# Altium schematic sheet MCU.SchDoc(MCU)
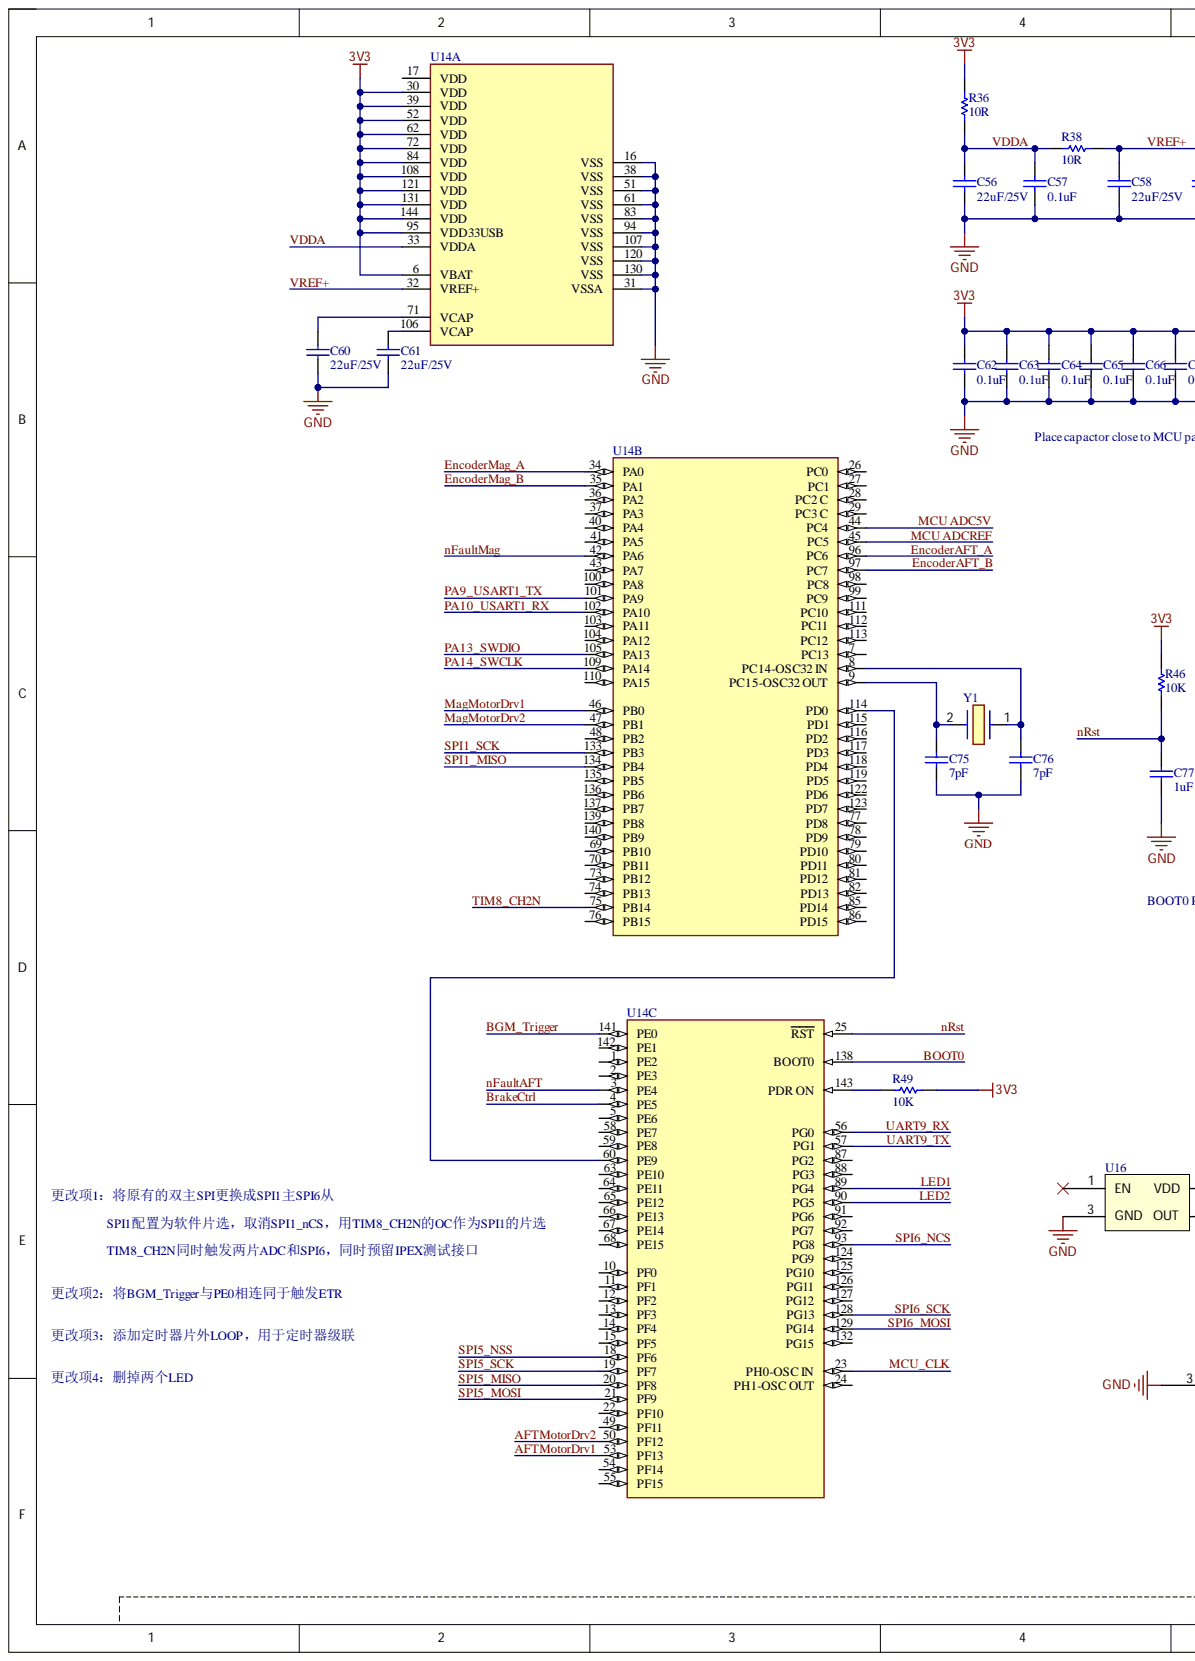
[diagram p0: MCU.SchDoc, left]
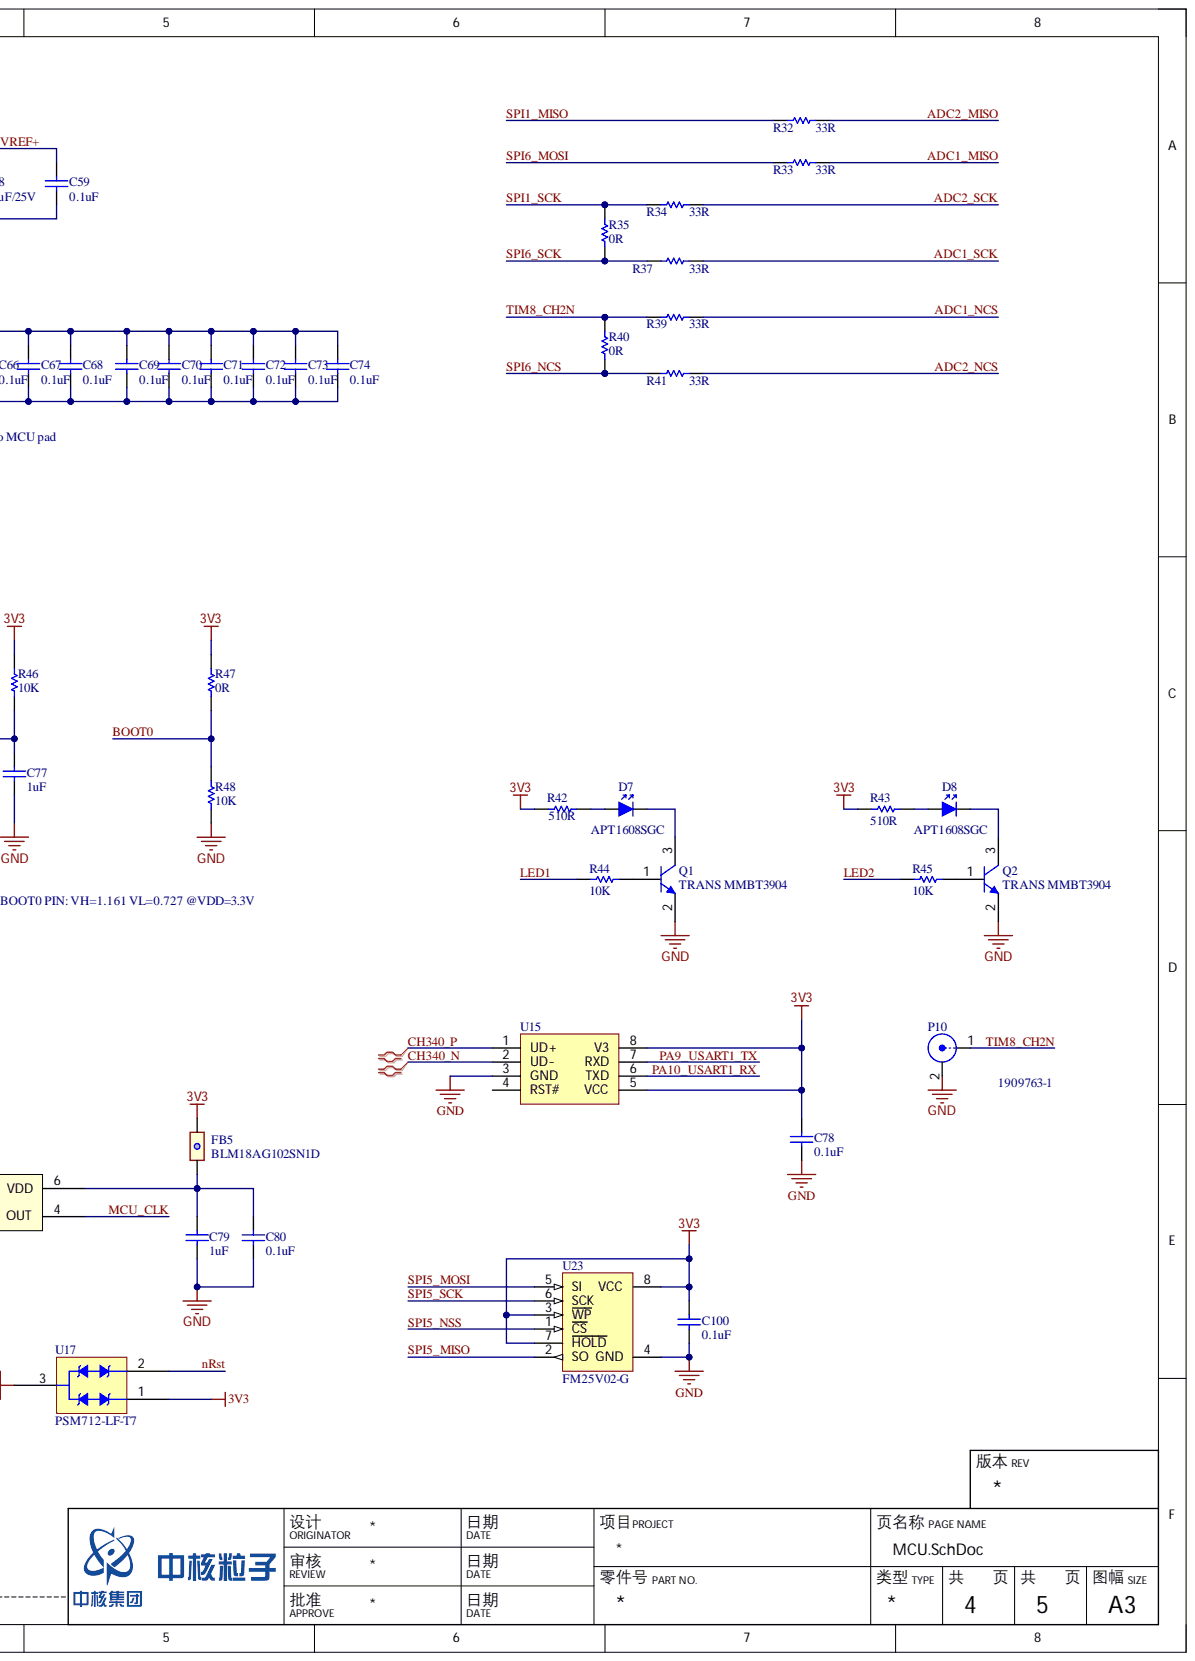
[diagram p1: MCU.SchDoc, right]

## Parts
C56  22uF/25V  [C1206(3216)]
C57  0.1uF  [C0603(1608)]
C58  22uF/25V  [C1206(3216)]
C59  0.1uF  [C0603(1608)]
C60  22uF/25V  [C1206(3216)]
C61  22uF/25V  [C1206(3216)]
C62  0.1uF  [C0402(1005)]
C63  0.1uF  [C0402(1005)]
C64  0.1uF  [C0402(1005)]
C65  0.1uF  [C0402(1005)]
C66  0.1uF  [C0402(1005)]
C67  0.1uF  [C0402(1005)]
C68  0.1uF  [C0402(1005)]
C69  0.1uF  [C0402(1005)]
C70  0.1uF  [C0402(1005)]
C71  0.1uF  [C0402(1005)]
C72  0.1uF  [C0402(1005)]
C73  0.1uF  [C0402(1005)]
C74  0.1uF  [C0402(1005)]
C75  7pF  [C0603(1608)]
C76  7pF  [C0603(1608)]
C77  1uF  [C0402(1005)]
C78  0.1uF  [C0603(1608)]
C79  1uF  [C0402(1005)]
C80  0.1uF  [C0402(1005)]
C100  0.1uF  [C0402(1005)]
D7  APT1608SGC  [LED0603O]
D8  APT1608SGC  [LED0603O]
FB5  BLM18AG102SN1D  [FB0603(1608)]
P10  1909763-1  [1909763-1]
Q1  TRANS MMBT3904  [SOT-23-3]
Q2  TRANS MMBT3904  [SOT-23-3]
R32  33R  [R0402(1005)]
R33  33R  [R0402(1005)]
R34  33R  [R0402(1005)]
R35  0R  [R0402(1005)]
R36  10R  [R0603(1608)]
R37  33R  [R0402(1005)]
R38  10R  [R0603(1608)]
R39  33R  [R0402(1005)]
R40  0R  [R0402(1005)]
R41  33R  [R0402(1005)]
R42  510R  [R0603(1608)]
R43  510R  [R0603(1608)]
R44  10K  [R0603(1608)]
R45  10K  [R0603(1608)]
R46  10K  [R0603(1608)]
R47  0R  [R0603(1608)]
R48  10K  [R0603(1608)]
R49  10K  [R0402(1005)]
U14  (no value)  [LQFP144-1A-IPC_A]  (parts A,B,C)
U15  (no value)  [SOIC8]
U16  (no value)  [ECS-3225S33-250-FN-TR]
U17  PSM712-LF-T7  [SOT23-3]
U23  FM25V02-G  [FM25V02-G_SOP8]
Y1  (no value)  [SC32S7PF20PPM]

## Nets
3V3: C62.2 C63.2 C64.2 C65.2 C66.2 C67.2 C68.2 C69.2 C70.2 C71.2 C72.2 C73.2 C74.2 C78.2 C100.2 FB5.1 R36.2 R42.1 R43.1 R46.2 R47.2 R49.2 U14.6(VBAT) U14.30(VDD) U14.39(VDD) U14.52(VDD) U14.62(VDD) U14.72(VDD) U14.84(VDD) U14.95(VDD33USB) U14.108(VDD) U14.121(VDD) U14.131(VDD) U14.144(VDD) U15.5(VCC) U15.8(V3) U17.1 U23.3(WP) U23.7(HOLD) U23.8(VCC)
GND: C56.1 C57.1 C58.1 C59.1 C60.1 C61.1 C62.1 C63.1 C64.1 C65.1 C66.1 C67.1 C68.1 C69.1 C70.1 C71.1 C72.1 C73.1 C74.1 C75.1 C76.1 C77.1 C78.1 C79.1 C80.1 C100.1 P10.2 Q1.2 Q2.2 R48.1 U14.16(VSS) U14.31(VSSA) U14.38(VSS) U14.51(VSS) U14.61(VSS) U14.83(VSS) U14.94(VSS) U14.107(VSS) U14.120(VSS) U14.130(VSS) U15.3(GND) U16.3(GND) U17.3 U23.4(GND)
ADC1_MISO: R33.2
ADC1_NCS: R39.2
ADC1_SCK: R37.2
ADC2_MISO: R32.2
ADC2_NCS: R41.2
ADC2_SCK: R34.2
AFTMotorDrv1: U14.53(PF13)
AFTMotorDrv2: U14.50(PF12)
BGM_Trigger: U14.141(PE0)
BOOT0: R47.1 R48.2 U14.138(BOOT0)
BrakeCtrl: U14.4(PE5)
CH340_N: U15.2(UD-)
CH340_P: U15.1(UD+)
EncoderAFT_A: U14.96(PC6)
EncoderAFT_B: U14.97(PC7)
EncoderMag_A: U14.34(PA0)
EncoderMag_B: U14.35(PA1)
LED1: R44.2 U14.89(PG4)
LED2: R45.2 U14.90(PG5)
MagMotorDrv1: U14.46(PB0)
MagMotorDrv2: U14.47(PB1)
MCU ADC5V: U14.44(PC4)
MCU ADCREF: U14.45(PC5)
MCU_CLK: U14.23(PH0-OSC_IN) U16.4(OUT)
nFaultAFT: U14.3(PE4)
nFaultMag: U14.42(PA6)
nRst: C77.2 R46.1 U14.25(RST) U17.2
PA9_USART1_TX: U14.101(PA9) U15.7(RXD)
PA10_USART1_RX: U14.102(PA10) U15.6(TXD)
PA13_SWDIO: U14.105(PA13)
PA14_SWCLK: U14.109(PA14)
SPI1_MISO: R32.1 U14.134(PB4)
SPI1_SCK: R34.1 R35.2 U14.133(PB3)
SPI5_MISO: U14.20(PF8) U23.2(SO)
SPI5_MOSI: U14.21(PF9) U23.5(SI)
SPI5_NSS: U14.18(PF6) U23.1(CS)
SPI5_SCK: U14.19(PF7) U23.6(SCK)
SPI6_MOSI: R33.1 U14.129(PG14)
SPI6_NCS: R40.1 R41.1 U14.93(PG8)
SPI6_SCK: R35.1 R37.1 U14.128(PG13)
TIM8_CH2N: P10.1 R39.1 R40.2 U14.75(PB14)
UART9_RX: U14.56(PG0)
UART9_TX: U14.57(PG1)
VDDA: C56.2 C57.2 R36.1 R38.2 U14.33(VDDA)
VREF+: C58.2 C59.2 R38.1 U14.32(VREF+)
NetC60_2: C60.2 U14.71(VCAP)
NetC61_2: C61.2 U14.106(VCAP)
NetC75_2: C75.2 U14.9(PC15-OSC32_OUT) Y1.2
NetC76_2: C76.2 U14.8(PC14-OSC32_IN) Y1.1
NetC79_2: C79.2 C80.2 FB5.2 U16.6(VDD)
NetD7_1: D7.1 R42.2
NetD7_2: D7.2 Q1.3
NetD8_1: D8.1 R43.2
NetD8_2: D8.2 Q2.3
NetQ1_1: Q1.1 R44.1
NetQ2_1: Q2.1 R45.1
NetR49_1: R49.1 U14.143(PDR_ON)
NetU14_60: U14.60(PE9) U14.114(PD0)

Not connected: U14 1(PE2) 2(PE3) 5(PE6) 7(PC13) 10(PF0) 11(PF1) 12(PF2) 13(PF3) 14(PF4) 15(PF5) 17(VDD) 22(PF10) 24(PH1-OSC_OUT) 26(PC0) 27(PC1) 28(PC2_C) 29(PC3_C) 36(PA2) 37(PA3) 40(PA4) 41(PA5) 43(PA7) 48(PB2) 49(PF11) 54(PF14) 55(PF15) 58(PE7) 59(PE8) 63(PE10) 64(PE11) 65(PE12) 66(PE13) 67(PE14) 68(PE15) 69(PB10) 70(PB11) 73(PB12) 74(PB13) 76(PB15) 77(PD8) 78(PD9) 79(PD10) 80(PD11) 81(PD12) 82(PD13) 85(PD14) 86(PD15) 87(PG2) 88(PG3) 91(PG6) 92(PG7) 98(PC8) 99(PC9) 100(PA8) 103(PA11) 104(PA12) 110(PA15) 111(PC10) 112(PC11) 113(PC12) 115(PD1) 116(PD2) 117(PD3) 118(PD4) 119(PD5) 122(PD6) 123(PD7) 124(PG9) 125(PG10) 126(PG11) 127(PG12) 132(PG15) 135(PB5) 136(PB6) 137(PB7) 139(PB8) 140(PB9) 142(PE1); U15 4(RST#); U16 1(EN)

## Library data of the parts
C56 C58 C60 C61 = Cap 22uF 1206-25V: 1206 [3216 公制], 22 µF, 25 V, ± 10%, X5R, GRM系列; 价格: ¥2.84@10; 制造商: MURATA; 名称: 瓷片电容; 型号: GRM31CR61E226KE15L; Component Kind: Standard; Library Name: RCL.SchLib; 供应商及库存编号: e络盟 1797010
C57 C59 = Cap 0.1uF 0603: ceramic 25V; 价格: ￥0.092@100; 制造商: KEMET; 型号: C0603C104K3RACTU; Component Kind: Standard; Library Name: RCL.SchLib; 供应商及库存编号: e络盟 1650835
C62-C74 C100 = Cap 0.1uF 0402-10V: ceramic 10V; 价格: ¥0.1@100; 制造商: KEMET; 型号: C0402C104K8RACTU; Component Kind: Standard; Library Name: RCL_release.SchLib; 供应商及库存编号: e络盟 2429351
C75 C76 = Cap 7pF 0603-50V C0G: ceramic 50V C0G; 制造商: muRata; 型号: GRM1885C1H7R0CA01D; Component Kind: Standard; Library Name: RCL_release.SchLib
C77 C79 = Cap 1uF 0402-10V: ceramic 10V; 价格: ¥0.19@100; 制造商: KEMET; 型号: C0402C105K8PACTU; Component Kind: Standard; Library Name: RCL_release.SchLib; 供应商及库存编号: e络盟 1793827
C78 = Cap 0.1uF 0603-10V: ceramic 10V; 价格: ¥0.092@100; 制造商: MULTICOMP; 型号: MC0603B104K100CT; Component Kind: Standard; Library Name: RCL_release.SchLib; 供应商及库存编号: e络盟 2627427
C80 = Cap 0.1uF 0402-25V: ceramic 25V; 价格: ¥0.33@100; 制造商: KEMET; 型号: C0402C104M3RACTU; Component Kind: Standard; Library Name: RCL_release.SchLib; 供应商及库存编号: e络盟 2528342
D7 D8 = LED APT1608SGC: RUNLED, Green, 0603; 价格: $0.036@100; 制造商: Kingbright; Component Kind: Standard; Library Name: Transistor_release.SchLib; 供应商及库存编号: digikey 754-1121-1-ND; 型号: APT1608SGC
FB5 = FB-1K@100MHz 0603: FERRITE BEAD 1 KOHM 0603 1LN; 价格: ¥0.25@100; 制造商: MURATA; 名称: 磁珠; Component Kind: Standard; Library Name: RCL_release.SchLib; 供应商及库存编号: e络盟 1515671; 型号: BLM18AG102SN1D
P10 = 1909763-1: IPEX PCB; Component Kind: Standard; Library Name: Connector_temp.SchLib
Q1 Q2 = MMBT3904: NPN 40V 0.2A SOT23; 价格: ￥0.5184@100; 制造商: Diodes; 型号: MMBT3904-7-F; Component Kind: Standard; Library Name: Transistor_release.SchLib; 供应商及库存编号: digikey MMBT3904-FDICT-ND
R32-R34 R37 R39 R41 = Res 33R 0402: Res ±1%; Component Kind: Standard; Library Name: RCL_release.SchLib
R35 R40 = Res 0R 0402: Res ±1%; Component Kind: Standard; Library Name: RCL_release.SchLib
R36 R38 = Res 10R 0603: Res ±1%; Component Kind: Standard; Library Name: RCL.SchLib
R42 R43 = Res 510R 0603: Res ±1%; Component Kind: Standard; Library Name: RCL_release.SchLib
R44-R46 R48 = Res 10K 0603: Res ±1%; 名称: 贴片电阻; Component Kind: Standard; Library Name: RCL_release.SchLib
R47 = Res 0R 0603: Res ±1%; Component Kind: Standard; Library Name: RCL_release.SchLib
R49 = Res 10K 0402: Res ±1%; Component Kind: Standard; Library Name: RCL_release.SchLib
U14 = STM32H723ZGT6: IC MCU 32BIT 1MB FLASH 144LQFP; Component Kind: Standard; Library Name: IC_release.SchLib
U15 = CH340N: USB转TTL串口; Component Kind: Standard; Library Name: IC_release.SchLib
U16 = ECS-3225S33-250-FN-TR: OSC XO 25.0000MHZ HCMOS SMD; 价格: $0.755@1K; 制造商: ECS Inc.; Component Kind: Standard; Library Name: IC_release.SchLib; 供应商及库存编号: digikey XC2221TR-ND; 型号: ECS-3225S33-250-FN-TR
U17 = PSM712-LF-T7: TVS; Component Kind: Standard; ComponentLink1Description: 供应商链接; ComponentLink1URL: http://www.szlcsc.com/product/details_33642.html; Library Name: Transistor_release.SchLib; Package: SOT-23(SOT-23-3); Supplier: LC; SuppliersPartNumber: C32677
Y1 = XTAL32.768KHz: SC32S-7PF20PPM; Component Kind: Standard; Library Name: IC_release.SchLib
U23 = FM25V02-G: Component Kind: Standard; Description: FM25V02-G; Library Name: IC_release.SchLib
